# Altium schematic sheet Sheet1.SchDoc(Sheet1)
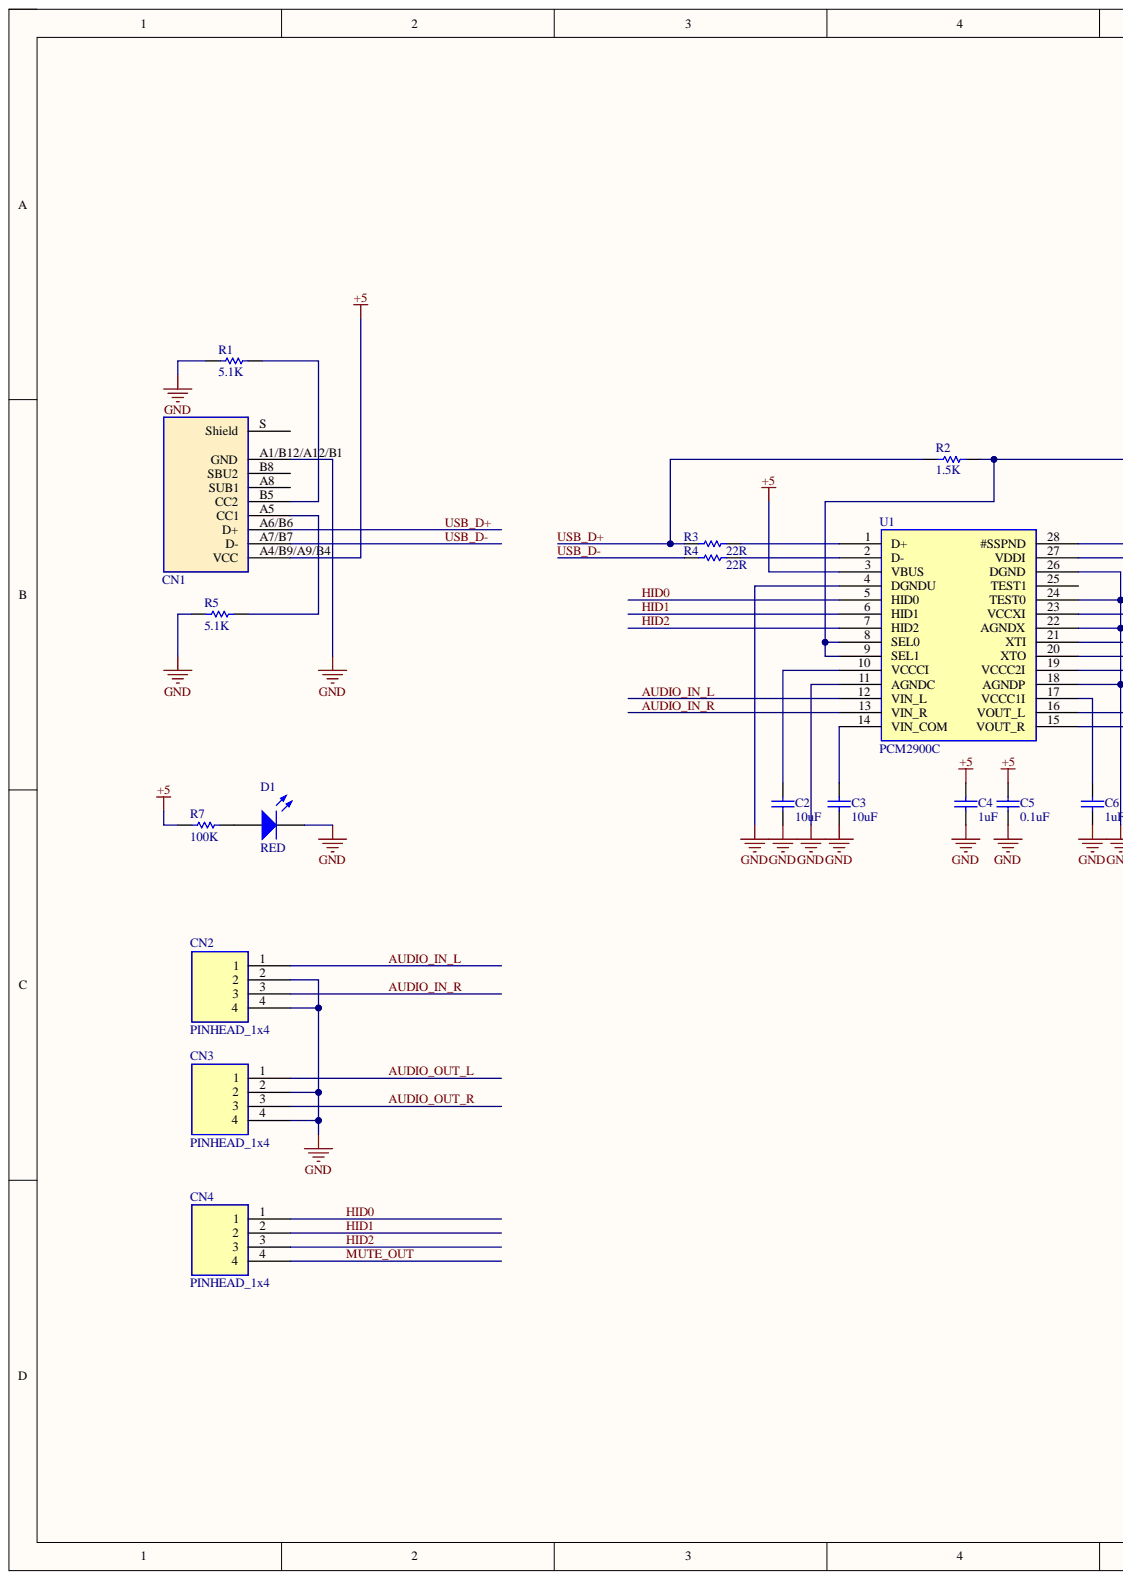
[diagram p0: Sheet1.SchDoc, left]
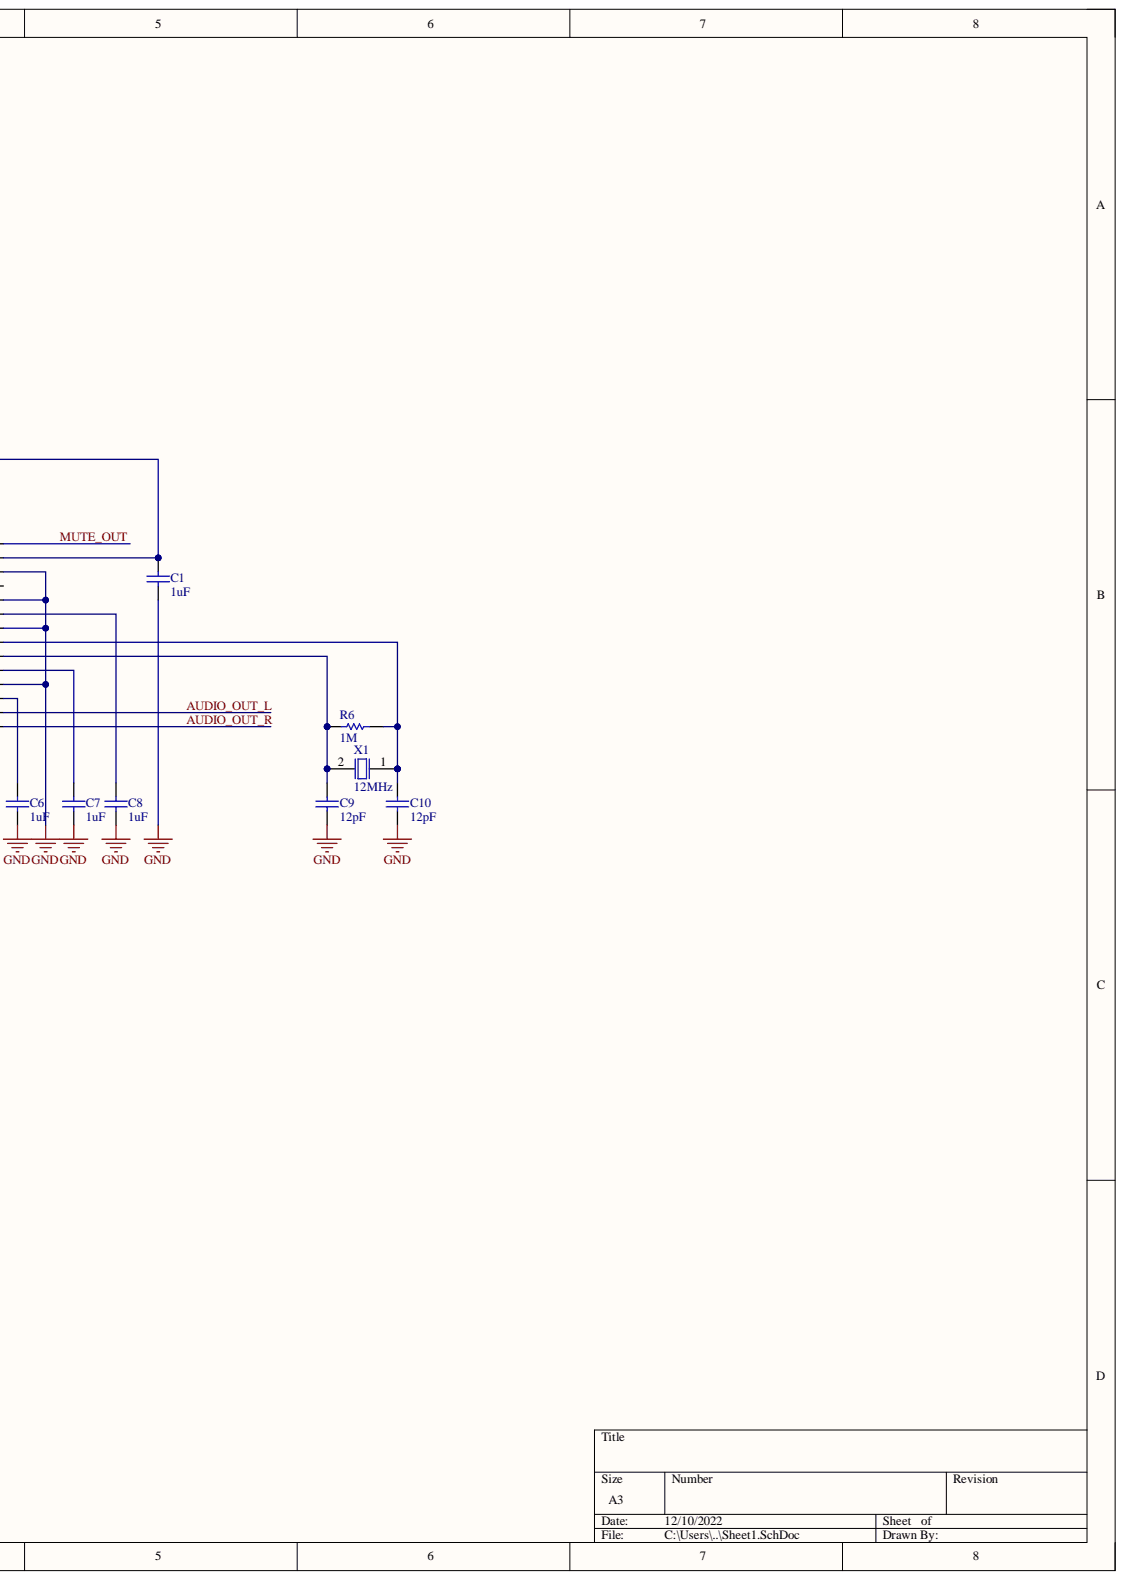
[diagram p1: Sheet1.SchDoc, right]

## Parts
C1  value 1uF  [Capacitor_1608]  (hidden comment: Condenser_1608)
C2  value 10uF  [Capacitor_1608]  (hidden comment: Condenser_1608)
C3  value 10uF  [Capacitor_1608]  (hidden comment: Condenser_1608)
C4  value 1uF  [Capacitor_1608]  (hidden comment: Condenser_1608)
C5  value 0.1uF  [Capacitor_1608]  (hidden comment: Condenser_1608)
C6  value 1uF  [Capacitor_1608]  (hidden comment: Condenser_1608)
C7  value 1uF  [Capacitor_1608]  (hidden comment: Condenser_1608)
C8  value 1uF  [Capacitor_1608]  (hidden comment: Condenser_1608)
C9  value 12pF  [Capacitor_1608]  (hidden comment: Condenser_1608)
C10  value 12pF  [Capacitor_1608]  (hidden comment: Condenser_1608)
CN1  USB2.0-Type-C  [TYPE-C-31-M-12]  (value not drawn)
CN2  PINHEAD_1x4  [PINHEAD_1x4]
CN3  PINHEAD_1x4  [PINHEAD_1x4]
CN4  PINHEAD_1x4  [PINHEAD_1x4]
D1  value RED  [LED_1608]  (hidden comment: LED_1608)
R1  value 5.1K  [Resistance_1608]  (hidden comment: Resista_1608)
R2  value 1.5K  [Resistance_1608]  (hidden comment: Resista_1608)
R3  value 22R  [Resistance_1608]  (hidden comment: Resista_1608)
R4  value 22R  [Resistance_1608]  (hidden comment: Resista_1608)
R5  value 5.1K  [Resistance_1608]  (hidden comment: Resista_1608)
R6  value 1M  [Resistance_1608]  (hidden comment: Resista_1608)
R7  value 100K  [Resistance_1608]  (hidden comment: Resista_1608)
U1  PCM2900C  [PCM2900C]
X1  value 12MHz  [FA-238V]  (hidden comment: FA-238V)

## Nets
+5: C4.2 C5.2 CN1.A4/B9/A9/B4(VCC) R7.1 U1.3(VBUS)
GND: C1.1 C2.1 C3.1 C4.1 C5.1 C6.1 C7.1 C8.1 C9.2 C10.2 CN1.A1/B12/A12/B1(GND) CN2.2 CN2.4 CN3.2 CN3.4 D1.C R1.1 R5.1 U1.4(DGNDU) U1.11(AGNDC) U1.18(AGNDP) U1.22(AGNDX) U1.24(TEST0) U1.26(DGND)
AUDIO_IN_L: CN2.1 U1.12(VIN_L)
AUDIO_IN_R: CN2.3 U1.13(VIN_R)
AUDIO_OUT_L: CN3.1 U1.16(VOUT_L)
AUDIO_OUT_R: CN3.3 U1.15(VOUT_R)
HID0: CN4.1 U1.5(HID0)
HID1: CN4.2 U1.6(HID1)
HID2: CN4.3 U1.7(HID2)
MUTE_OUT: CN4.4 U1.28(#SSPND)
USB_D+: CN1.A6/B6(D+) R2.1 R3.1
USB_D-: CN1.A7/B7(D-) R4.1
NetC1_2: C1.2 R2.2 U1.8(SEL0) U1.9(SEL1) U1.27(VDDI)
NetC2_2: C2.2 U1.10(VCCCI)
NetC3_2: C3.2 U1.14(VIN_COM)
NetC6_2: C6.2 U1.17(VCCC1I)
NetC7_2: C7.2 U1.19(VCCC2I)
NetC8_2: C8.2 U1.23(VCCXI)
NetC9_1: C9.1 R6.1 U1.20(XTO) X1.2
NetC10_1: C10.1 R6.2 U1.21(XTI) X1.1
NetCN1_A5: CN1.A5(CC1) R5.2
NetCN1_B5: CN1.B5(CC2) R1.2
NetD1_A: D1.A R7.2
NetR3_2: R3.2 U1.1(D+)
NetR4_2: R4.2 U1.2(D-)

Not connected: CN1 A8(SUB1) B8(SBU2) S(Shield); U1 25(TEST1)

## Library data of the parts
C1-C10 = Condenser_1608: Component Kind: Standard; Library Name: MyLaibrary.SchLib
CN1 = USB2.0-Type-C: Component Kind: Standard; Library Name: MyLaibrary.SchLib
CN2-CN4 = PINHEAD_1x4: Component Kind: Standard; Library Name: MyLaibrary.SchLib
D1 = LED_1608: Component Kind: Standard; Library Name: MyLaibrary.SchLib
R1-R7 = Resista_1608: Component Kind: Standard; Library Name: MyLaibrary.SchLib
U1 = PCM2900C: Component Kind: Standard; Value: PCM2900C; Library Name: MyLaibrary.SchLib
X1 = FA-238V: Component Kind: Standard; Library Name: MyLaibrary.SchLib
